# Altium schematic sheet XXXX-YYYY-RZZ-SCH.SchDot(XXXX-YYYY-RZZ-SCH)
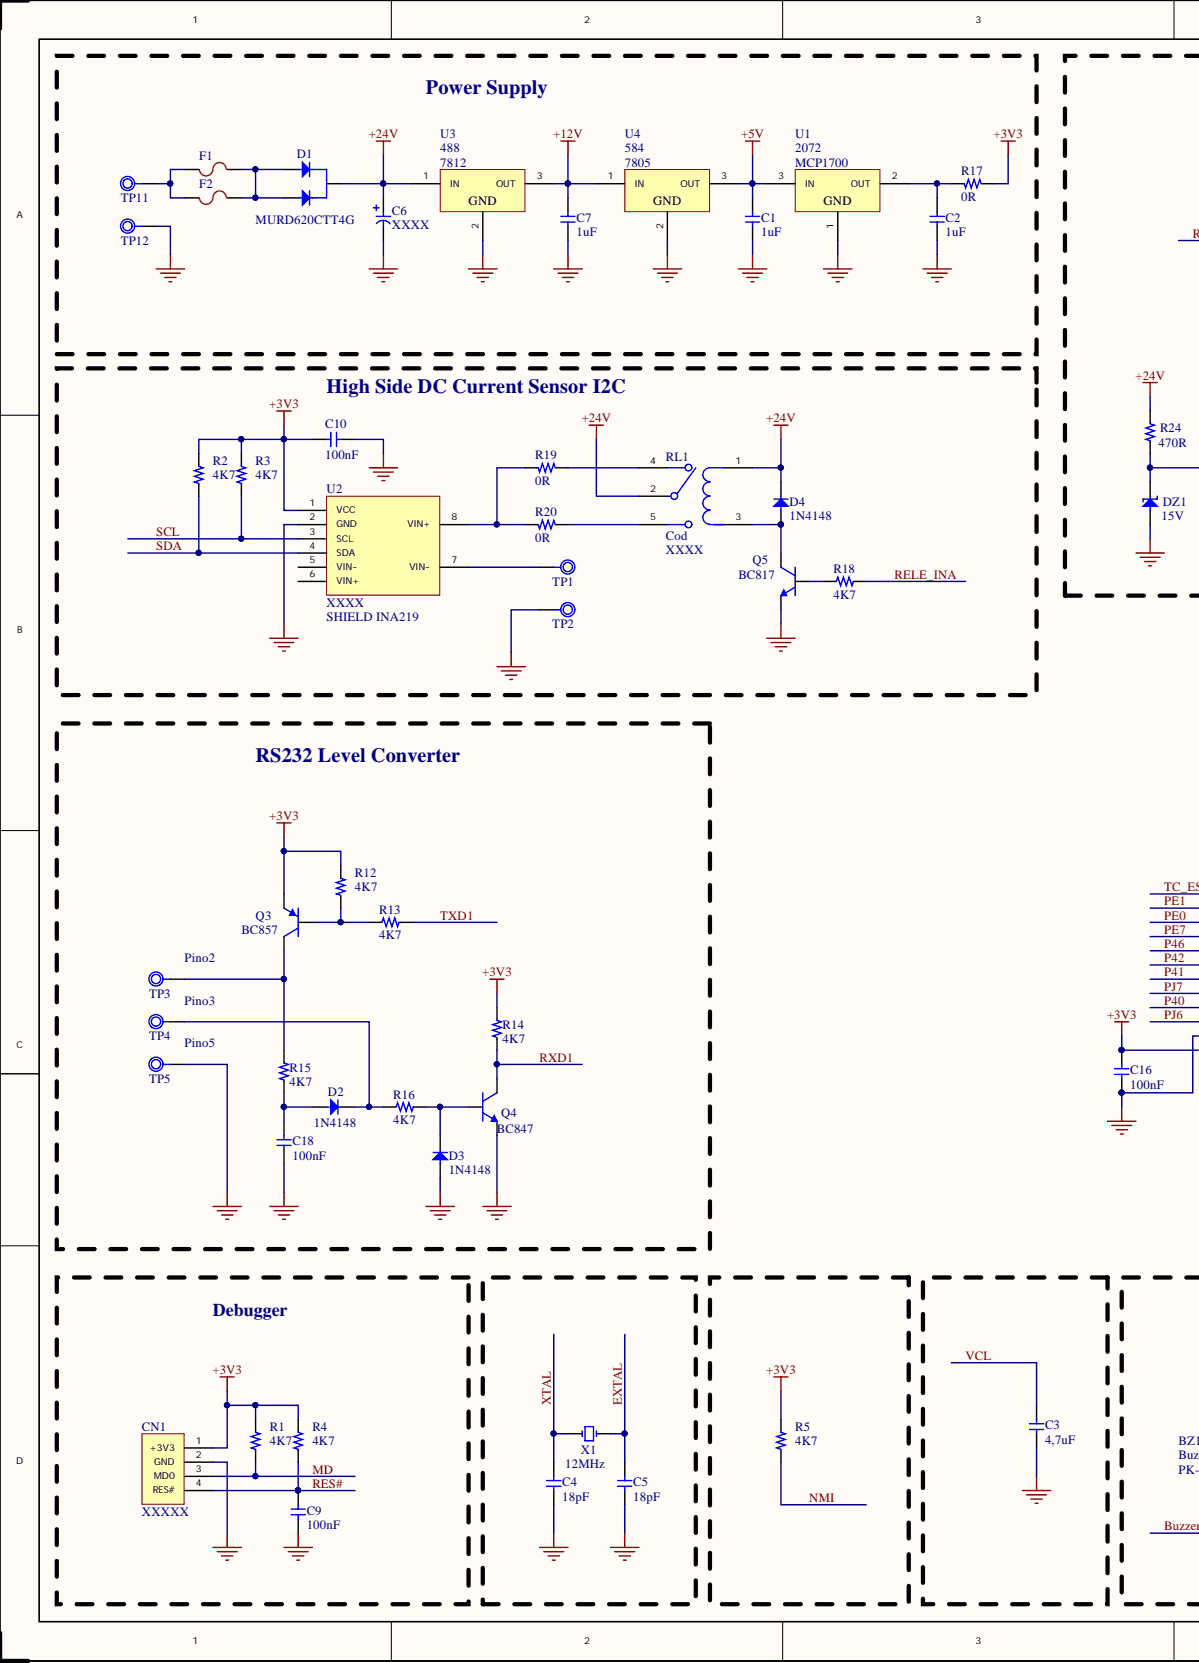
[diagram p0: XXXX-YYYY-RZZ-SCH.SchDot(XXXX-YYYY-RZZ-SCH), left]
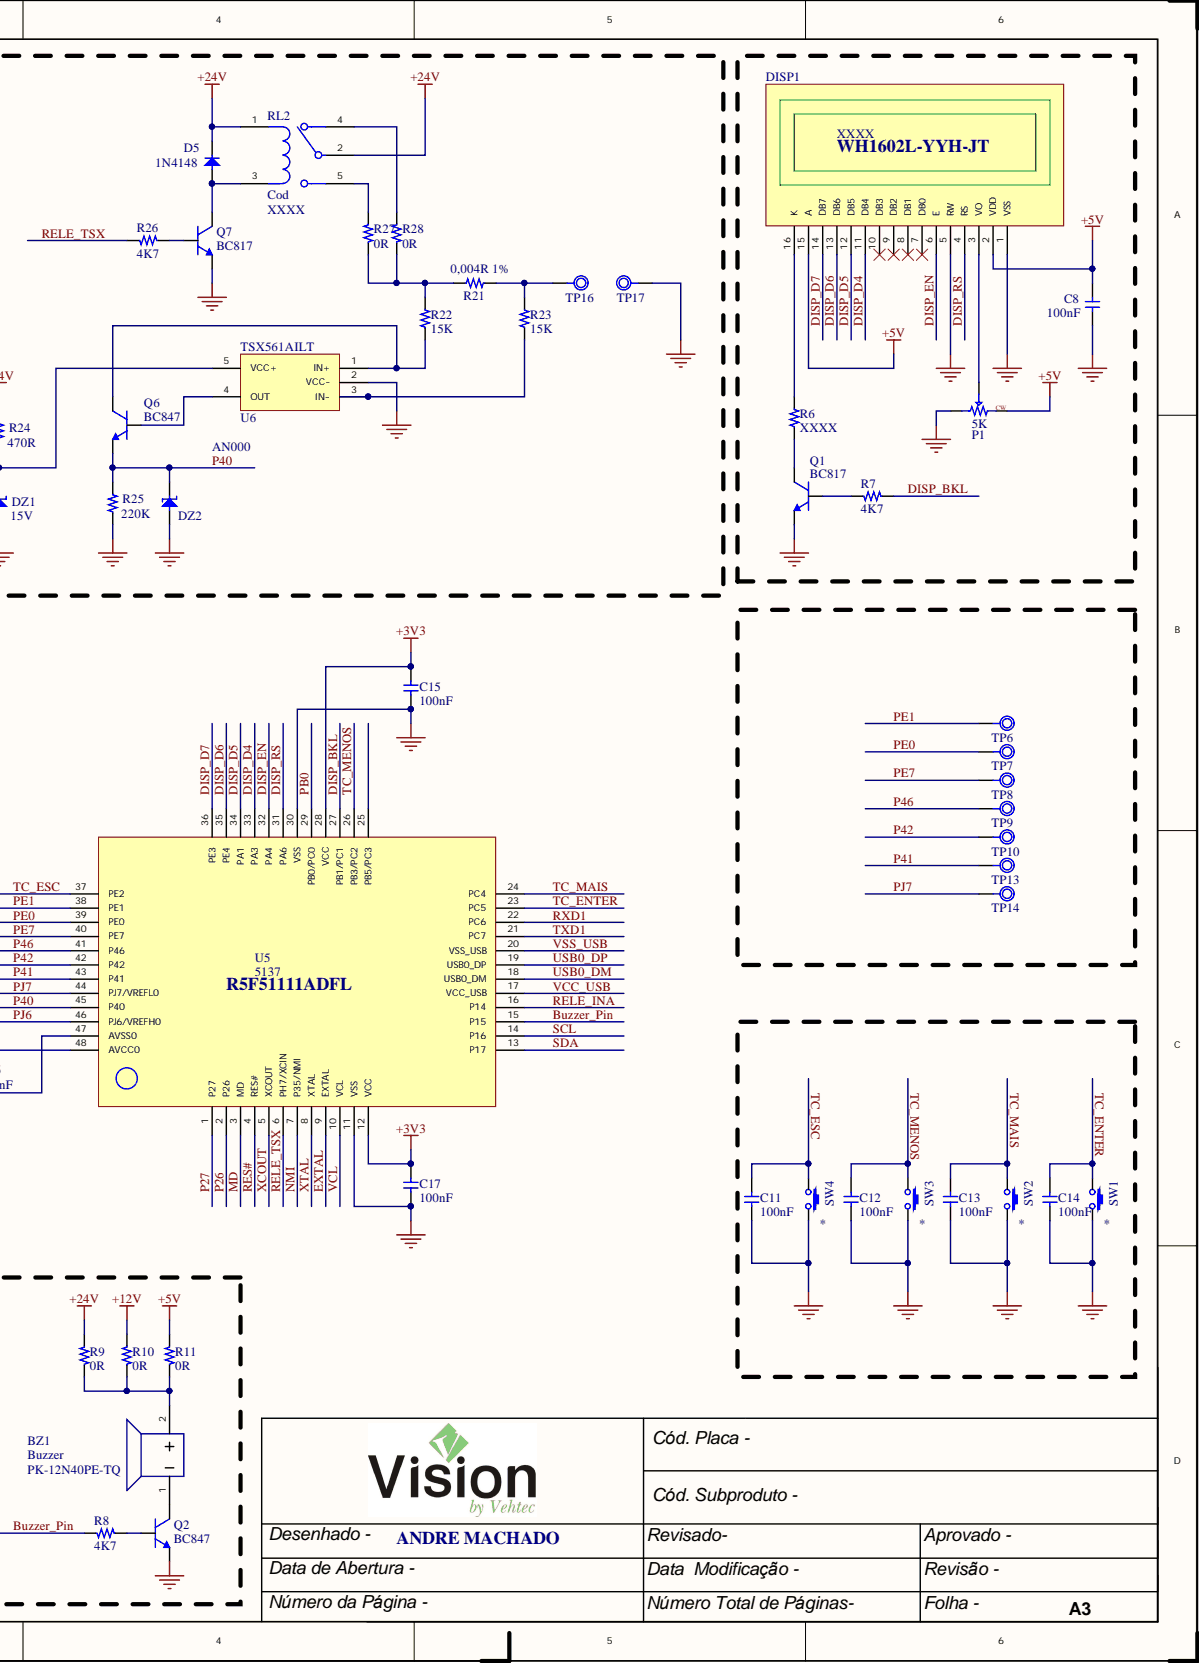
[diagram p1: XXXX-YYYY-RZZ-SCH.SchDot(XXXX-YYYY-RZZ-SCH), right]

## Parts
BZ1  Buzzer  VALOR: PK-12N40PE-TQ  [BUZZER PTH 13.8  X 7.5 - duplicate]
C1  VALOR: 1uF  [CAP CER SMD C0805]  (hidden comment: XXXX)
C2  VALOR: 1uF  [CAP CER SMD C0805]  (hidden comment: XXXX)
C3  VALOR: 4,7uF  [CAP CER SMD C0805]  (hidden comment: XXXX)
C4  VALOR: 18pF  [CAP CER SMD C0805]  (hidden comment: XXXX)
C5  VALOR: 18pF  [CAP CER SMD C0805]  (hidden comment: XXXX)
C6  XXXX  [CAP ELCO PTH 8.0 X 11.5 X 3.5]
C7  VALOR: 1uF  [CAP CER SMD C0805]  (hidden comment: XXXX)
C8  VALOR: 100nF  [CAP CER SMD C0805]  (hidden comment: XXXX)
C9  VALOR: 100nF  [CAP CER SMD C0805]  (hidden comment: XXXX)
C10  VALOR: 100nF  [CAP CER SMD C0805]  (hidden comment: XXXX)
C11  VALOR: 100nF  [CAP CER SMD C0805]  (hidden comment: XXXX)
C12  VALOR: 100nF  [CAP CER SMD C0805]  (hidden comment: XXXX)
C13  VALOR: 100nF  [CAP CER SMD C0805]  (hidden comment: XXXX)
C14  VALOR: 100nF  [CAP CER SMD C0805]  (hidden comment: XXXX)
C15  VALOR: 100nF  [CAP CER SMD C0805]  (hidden comment: XXXX)
C16  VALOR: 100nF  [CAP CER SMD C0805]  (hidden comment: XXXX)
C17  VALOR: 100nF  [CAP CER SMD C0805]  (hidden comment: XXXX)
C18  VALOR: 100nF  [CAP CER SMD C0805]  (hidden comment: XXXX)
CN1  XXXXX  [CON GRAVAÇÃO LINHA RX [lite]]
D1  MURD620CTT4G  [D-PAK STANDARD DIODO]
D2  1N4148  [IC SOD-80 (MINIMELF)]
D3  1N4148  [IC SOD-80 (MINIMELF)]
D4  1N4148  [IC SOD-80 (MINIMELF)]
D5  1N4148  [IC SOD-80 (MINIMELF)]
DISP1  XXXX  VALOR: WH1602L-YYH-JT  [DISPLAY 16X2 WH1602L-YYH-JT]
DZ1  VALOR: 15V  [IC SOD-80 (MINIMELF) [A]]  (hidden comment: Diodo Zener)
DZ2  Diodo Zener  [IC SOD-80 (MINIMELF) [A]]  (value not drawn)
F1  XXXX  [FUSIVEL SMD 2410]  (value not drawn)
F2  XXXX  [FUSIVEL SMD 2410]  (value not drawn)
P1  VALOR: 5K  [POT PTH 3296W VERTICAL STANDARD]  (hidden comment: Trimpot)
Q1  VALOR: BC817  [IC SOT-23-3]  (hidden comment: Trans NPN)
Q2  VALOR: BC847  [IC SOT-23-3]  (hidden comment: Trans NPN)
Q3  VALOR: BC857  [IC SOT-23-3]  (hidden comment: Trans PNP)
Q4  VALOR: BC847  [IC SOT-23-3]  (hidden comment: Trans NPN)
Q5  VALOR: BC817  [IC SOT-23-3]  (hidden comment: Trans NPN)
Q6  VALOR: BC847  [IC SOT-23-3]  (hidden comment: Trans NPN)
Q7  VALOR: BC817  [IC SOT-23-3]  (hidden comment: Trans NPN)
R1  VALOR: 4K7  [RES SMD R0805]  (hidden comment: XXXX)
R2  VALOR: 4K7  [RES SMD R0805]  (hidden comment: XXXX)
R3  VALOR: 4K7  [RES SMD R0805]  (hidden comment: XXXX)
R4  VALOR: 4K7  [RES SMD R0805]  (hidden comment: XXXX)
R5  VALOR: 4K7  [RES SMD R0805]  (hidden comment: XXXX)
R6  XXXX  [RES SMD R0805]
R7  VALOR: 4K7  [RES SMD R0805]  (hidden comment: XXXX)
R8  VALOR: 4K7  [RES SMD R0805]  (hidden comment: XXXX)
R9  VALOR: 0R  [RES SMD R0805]  (hidden comment: XXXX)
R10  VALOR: 0R  [RES SMD R0805]  (hidden comment: XXXX)
R11  VALOR: 0R  [RES SMD R0805]  (hidden comment: XXXX)
R12  VALOR: 4K7  [RES SMD R0805]  (hidden comment: XXXX)
R13  VALOR: 4K7  [RES SMD R0805]  (hidden comment: XXXX)
R14  VALOR: 4K7  [RES SMD R0805]  (hidden comment: XXXX)
R15  VALOR: 4K7  [RES SMD R0805]  (hidden comment: XXXX)
R16  VALOR: 4K7  [RES SMD R0805]  (hidden comment: XXXX)
R17  VALOR: 0R  [RES SMD R0805]  (hidden comment: XXXX)
R18  VALOR: 4K7  [RES SMD R0805]  (hidden comment: XXXX)
R19  VALOR: 0R  [RES SMD R0805]  (hidden comment: XXXX)
R20  VALOR: 0R  [RES SMD R0805]  (hidden comment: XXXX)
R21  VALOR: 0,004R 1%  [RES SMD R2512]  (hidden comment: Res_Geral)
R22  VALOR: 15K  [RES SMD R0805 [A]]  (hidden comment: Res_Geral)
R23  VALOR: 15K  [RES SMD R0805 [A]]  (hidden comment: Res_Geral)
R24  VALOR: 470R  [RES SMD R0805 [A]]  (hidden comment: Res_Geral)
R25  VALOR: 220K  [RES SMD R0805 [A]]  (hidden comment: Res_Geral)
R26  VALOR: 4K7  [RES SMD R0805]  (hidden comment: XXXX)
R27  VALOR: 0R  [RES SMD R0805]  (hidden comment: XXXX)
R28  VALOR: 0R  [RES SMD R0805]  (hidden comment: XXXX)
RL1  Cod  VALOR: XXXX  [RELE 5 PINOS]
RL2  Cod  VALOR: XXXX  [RELE 5 PINOS]
SW1  CHAVE TÁCTIL 6x6x4,3  [CHAVE TÁCTIL PTH 6X6X4.3 180°]  (value not drawn)
SW2  CHAVE TÁCTIL 6x6x4,3  [CHAVE TÁCTIL PTH 6X6X4.3 180°]  (value not drawn)
SW3  CHAVE TÁCTIL 6x6x4,3  [CHAVE TÁCTIL PTH 6X6X4.3 180°]  (value not drawn)
SW4  CHAVE TÁCTIL 6x6x4,3  [CHAVE TÁCTIL PTH 6X6X4.3 180°]  (value not drawn)
TP1  TestPoint  [Testpoint]  (value not drawn)
TP2  TestPoint  [Testpoint]  (value not drawn)
TP3  TestPoint  [Testpoint]  (value not drawn)
TP4  TestPoint  [Testpoint]  (value not drawn)
TP5  TestPoint  [Testpoint]  (value not drawn)
TP6  TestPoint  [Testpoint]  (value not drawn)
TP7  TestPoint  [Testpoint]  (value not drawn)
TP8  TestPoint  [Testpoint]  (value not drawn)
TP9  TestPoint  [Testpoint]  (value not drawn)
TP10  TestPoint  [Testpoint]  (value not drawn)
TP11  TestPoint  [Testpoint]  (value not drawn)
TP12  TestPoint  [Testpoint]  (value not drawn)
TP13  TestPoint  [Testpoint]  (value not drawn)
TP14  TestPoint  [Testpoint]  (value not drawn)
TP16  TestPoint  [Testpoint]  (value not drawn)
TP17  TestPoint  [Testpoint]  (value not drawn)
U1  2072  VALOR: MCP1700  [IC SOT-23-3]
U2  XXXX  [Shield INA219]
U3  488  VALOR: 7812  [IC TO-220-3B-H]
U4  584  VALOR: 7805  [IC TO-220-3B-H]
U5  5137  VALOR: R5F51111ADFL  [IC LQFP-48]
U6  XXXX  [IC SOT-23-5]  (value not drawn)
X1  VALOR: 12MHz  [CRISTAL SMD HC-49/US]  (hidden comment: Cristal 2P)

## Nets
+3V3: C10.2 C15.1 C16.1 C17.2 CN1.1(+3V3) Q3.2 R1.2 R2.2 R3.2 R4.2 R5.2 R12.2 R14.2 R17.1 U2.1(VCC) U5.12(VCC) U5.28(VCC) U5.48(AVCC0)
+5V: C1.2 C8.2 DISP1.2(VDD) DISP1.15(A) P1.3 R11.2 U1.3 U4.3
+12V: C7.2 R10.2 U3.3 U4.1
+24V: C6.2 D1.2 D4.2 D5.2 R9.2 R24.2 RL1.1 RL1.2 RL2.1 RL2.2 U3.1
GND: C1.1 C2.1 C3.1 C4.1 C5.1 C6.1 C7.1 C8.1 C9.1 C10.1 C11.1 C12.1 C13.1 C14.1 C15.2 C16.2 C17.1 C18.2 CN1.2(GND) D3.1 DISP1.1(VSS) DISP1.5(RW) DZ1.1 DZ2.1 P1.1 Q1.2 Q2.2 Q4.2 Q5.2 Q7.2 R25.1 SW1.2 SW2.2 SW3.2 SW4.2 TP2.1 TP5.1 TP12.1 TP17.1 U1.1 U2.2(GND) U3.2 U4.2 U5.11(VSS) U5.30(VSS) U5.47(AVSS0) U6.2(VCC-)
BUZZER_PIN: R8.1 U5.15(P15)
DISP_BKL: R7.2 U5.27(PB1/PC1)
DISP_D4: DISP1.11(DB4) U5.33(PA3)
DISP_D5: DISP1.12(DB5) U5.34(PA1)
DISP_D6: DISP1.13(DB6) U5.35(PE4)
DISP_D7: DISP1.14(DB7) U5.36(PE3)
DISP_EN: DISP1.6(E) U5.32(PA4)
DISP_RS: DISP1.4(RS) U5.31(PA6)
EXTAL: C5.2 U5.9(EXTAL) X1.2
MD: CN1.3(MD0) R1.1 U5.3(MD)
NMI: R5.1 U5.7(P35/NMI)
P26: U5.2(P26)
P27: U5.1(P27)
P40: DZ2.2 Q6.2 R25.2 U5.45(P40)
P41: TP13.1 U5.43(P41)
P42: TP10.1 U5.42(P42)
P46: TP9.1 U5.41(P46)
PB0: U5.29(PB0/PC0)
PE0: TP7.1 U5.39(PE0)
PE1: TP6.1 U5.38(PE1)
PE7: TP8.1 U5.40(PE7)
PJ6: U5.46(PJ6/VREFH0)
PJ7: TP14.1 U5.44(PJ7/VREFL0)
RELE_INA: R18.1 U5.16(P14)
RELE_TSX: R26.1 U5.6(PH7/XCIN)
RES#: C9.2 CN1.4(RES#) R4.1 U5.4(RES#)
RXD1: Q4.3 R14.1 U5.22(PC6)
SCL: R3.1 U2.3(SCL) U5.14(P16)
SDA: R2.1 U2.4(SDA) U5.13(P17)
TC_ENTER: C14.2 SW1.1 U5.23(PC5)
TC_ESC: C11.2 SW4.1 U5.37(PE2)
TC_MAIS: C13.2 SW2.1 U5.24(PC4)
TC_MENOS: C12.2 SW3.1 U5.26(PB3/PC2)
TXD1: R13.2 U5.21(PC7)
USB0_DM: U5.18(USB0_DM)
USB0_DP: U5.19(USB0_DP)
VCC_USB: U5.17(VCC_USB)
VCL: C3.2 U5.10(VCL)
VSS_USB: U5.20(VSS_USB)
XCOUT: U5.5(XCOUT)
XTAL: C4.2 U5.8(XTAL) X1.1
NetBZ1_1: BZ1.1 Q2.3
NetBZ1_2: BZ1.2 R9.1 R10.1 R11.1
NetC2_2: C2.2 R17.2 U1.2
NetC18_1: C18.1 D2.1 R15.1
NetD1_1: D1.1 D1.3 F1.2 F2.2
NetD2_2: D2.2 R16.1 TP4.1
NetD3_2: D3.2 Q4.1 R16.2
NetD4_1: D4.1 Q5.3 RL1.3
NetD5_1: D5.1 Q7.3 RL2.3
NetDISP1_3: DISP1.3(VO) P1.2
NetDISP1_16: DISP1.16(K) R6.2
NetDZ1_2: DZ1.2 R24.1 U6.5(VCC+)
NetF1_1: F1.1 F2.1 TP11.1
NetQ1_1: Q1.1 R7.1
NetQ1_3: Q1.3 R6.1
NetQ2_1: Q2.1 R8.2
NetQ3_1: Q3.1 R12.1 R13.1
NetQ3_3: Q3.3 R15.2 TP3.1
NetQ5_1: Q5.1 R18.2
NetQ6_1: Q6.1 U6.4(OUT)
NetQ6_3: Q6.3 R22.1 U6.1(IN+)
NetQ7_1: Q7.1 R26.2
NetR19_1: R19.1 RL1.4
NetR19_2: R19.2 R20.2 U2.8(VIN+)
NetR20_1: R20.1 RL1.5
NetR21_1: R21.1 R22.2 R27.2 R28.2
NetR21_2: R21.2 R23.2 TP16.1
NetR23_1: R23.1 U6.3(IN-)
NetR27_1: R27.1 RL2.5
NetR28_1: R28.1 RL2.4
NetTP1_1: TP1.1 U2.7(VIN-)

Not connected: DISP1 7(DB0) 8(DB1) 9(DB2) 10(DB3); U2 5(VIN-) 6(VIN+); U5 25(PB5/PC3)

## Library data of the parts
BZ1 = Buzzer: Buzzer PK-12N40PE-TQ; Component Kind: Standard; Library Name: [Vision2018] Xtal_Osc.SchLib
C1-C5 C7-C18 = Capacitor Ceramic: Non-polarized; Component Kind: Standard; Library Name: [Vision2018] Capacitores.SchLib
C6 = Capacitor Electrolytic: Polarized; Component Kind: Standard; Library Name: [Vision2018] Capacitores.SchLib
CN1 = Con Gravação Linha RX  [lite]: Component Kind: Standard; Description: CON GRAVAÇÃO LINHA RX  [lite]; Library Name: [Vision2018] Conector.SchLib
D1 = Diodo MURD620CTT4G D-PAK: DIODO RETIFICADOR ULTRA-RÁPIDO 6A 200V; Manufacturer: ON Semiconductor; Configuration: Dual Common Cathode; Cód. Effective: 4506; Forward Voltage Drop: 1.2 V; Max Surge Current: 50 A; Recovery Time: 35 ns; Reverse Current IR: 5 uA; Category: Rectifiers; Component Kind: Standard; ComponentLink1Description: http://www.mouser.com/ds/2/308/MURD620CT-D-221972.pdf; ComponentLink1URL: http://www.mouser.com/ds/2/308/MURD620CT-D-221972.pdf; Forward Continuous Current: 6 A; Manufacturer Part Number: MURD620CTT4G; Maximum Operating Temperature: + 175 C; Minimum Operating Temperature: - 65 C; Mounting Style: SMD/SMT; Package / Case: TO-252-3 (DPAK); Packaging: Reel; Product: Ultra Fast Recovery Rectifiers; Product Category: Rectifiers- UltraFast; Reeling: True; Reverse Voltage: 200 V; RoHS: RoHS Compliant; RoHS - Mouser: Y; Library Name: Bib_Esquemático.SchLib
D2-D5 = Diode Retifier Single: DIODO RETIFICADOR; Component Kind: Standard; Library Name: [Vision2018] Diodo.SchLib
DISP1 = Display 16X2 WH1602L-YYH-JT: Component Kind: Standard; Description: DISPLAY 16X2 WH1602L-YYH-JT; Library Name: [Vision2018] CircuitoIntegrado.SchLib
F1 F2 = Fusível: FUSIVEL; Component Kind: Standard; VALOR: XXXX; Library Name: [Vision2018] Fuses.SchLib
P1 = Trimpot: TRIMPOT HORIZONTAL; Component Kind: Standard; Library Name: [Vision2018] Resistior.SchLib
Q1 Q2 Q4-Q7 = Trans NPN: TRANSISTOR NPN; Component Kind: Standard; Library Name: [Vision2018] Transistor.SchLib
Q3 = Trans PNP: TRANSISTOR PNP; Component Kind: Standard; Library Name: [Vision2018] Transistor.SchLib
R1-R28 = Res_Geral: RESISTOR; Component Kind: Standard; Library Name: [Vision2018] Resistior.SchLib
  R6: VALOR: XXXX
RL1 RL2 = Rele SPDT: Component Kind: Standard; Description: RELE SPDT; Library Name: [Vision2018] Relays.SchLib
U1 = REG MCP1700 SOT-23-3: LOW QUIESCENT CURRENT LDO; Component Kind: Standard; Library Name: [Vision2018] CircuitoIntegrado.SchLib
U2 = SHIELD INA219: Component Kind: Standard; Description: SHIELD INA219; VALOR: SHIELD INA219; Library Name: [Vision2018] CircuitoIntegrado.SchLib
U3 U4 = REG 78XX TO220-3: REGULADOR DE TENSÃO; Component Kind: Standard; Library Name: [Vision2018] CircuitoIntegrado.SchLib
U5 = R5F51111ADFL LQFP-4: MICROCONTROLADOR 32 MHz 32-BIT RX; Component Kind: Standard; Library Name: [Vision2018] CircuitoIntegrado.SchLib
U6 = TSX561AILT: Component Kind: Standard; Description: TSX561AILT; VALOR: TSX561AILT; Library Name: [Vision2018] CircuitoIntegrado.SchLib
X1 = Cristal 2P: CRISTAL; Component Kind: Standard; Library Name: [Vision2018] Xtal_Osc.SchLib
DZ1 DZ2 = Diodo Zener: Component Kind: Standard; Description: DIODO ZENER; Library Name: [Vision2018] Diodo.SchLib
SW1-SW4 = CHAVE TÁCTIL 6x6x4,3: Component Kind: Standard; Description: CHAVE TÁCTIL 6x6x4,3; Library Name: [Vision2018] TactSwitch.SchLib
TP1-TP14 TP16 TP17 = TestPoint: Component Kind: Standard; Description: TESTPOINT; Library Name: Biblioteca Integrada.IntLib
